# Altium schematic sheet Sheet_Robo_Hand_main.SchDoc(Sheet_Robo_Hand_main)
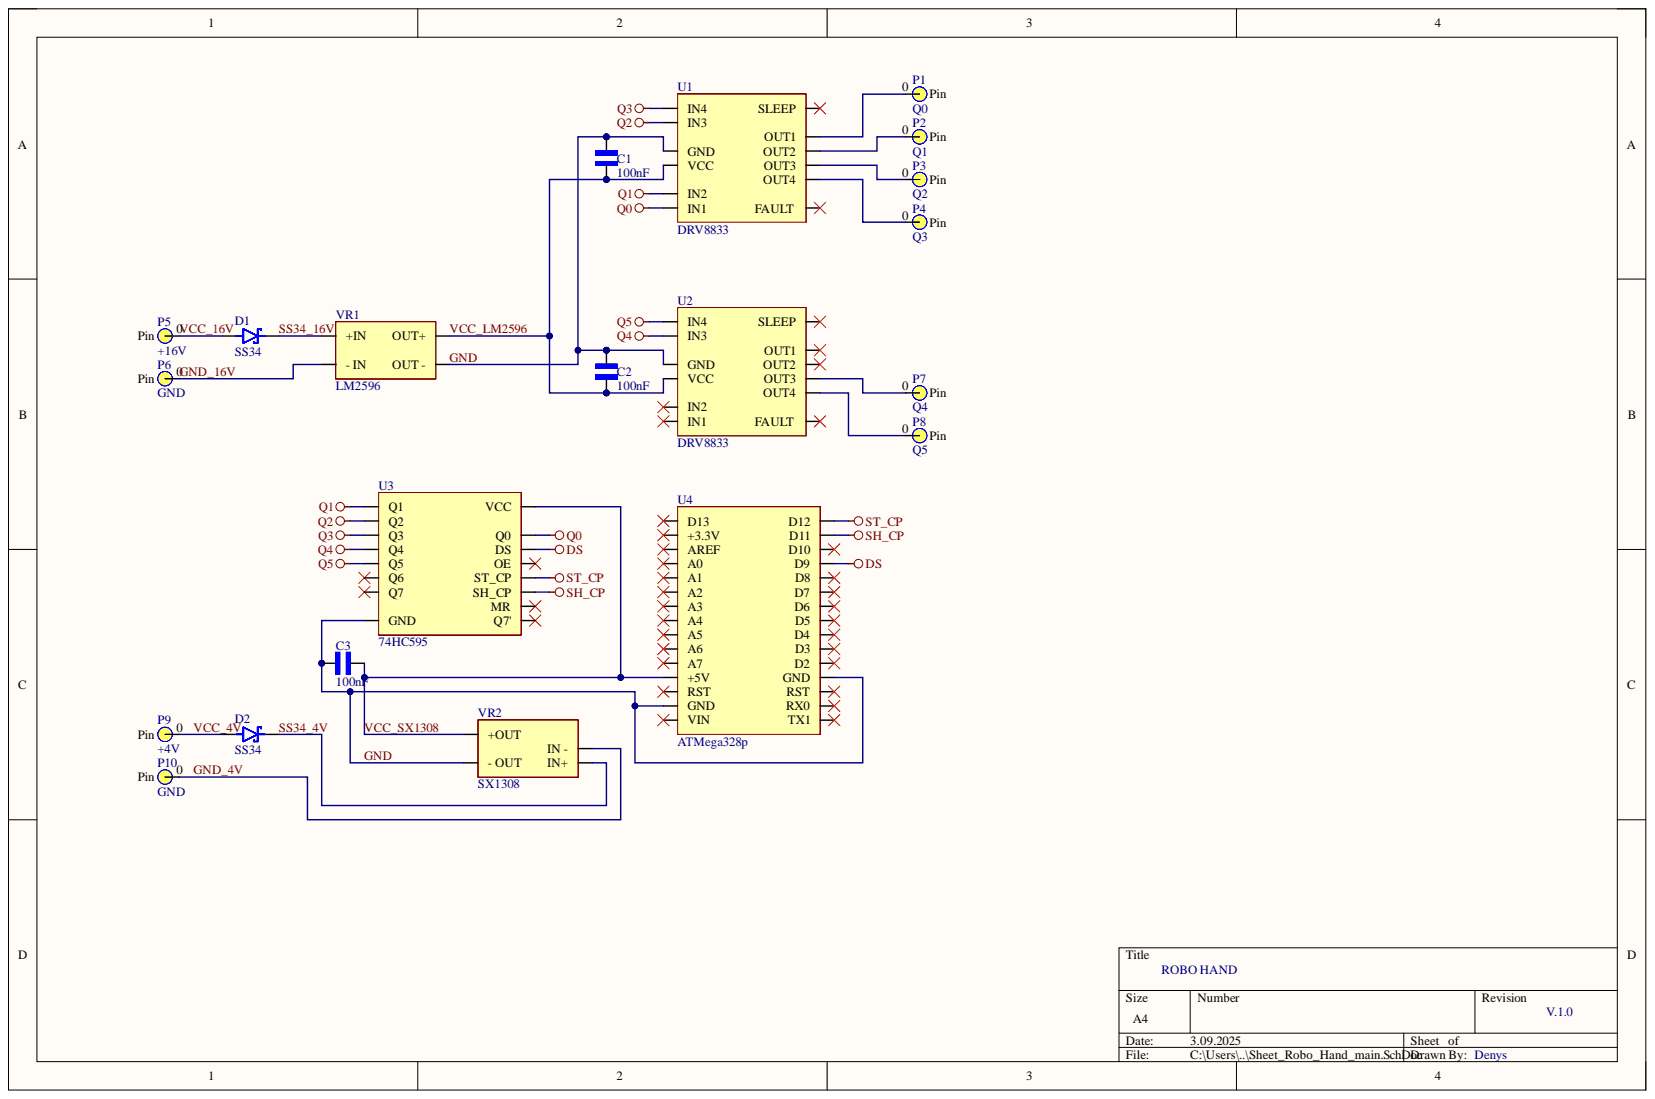
[diagram p0: Sheet_Robo_Hand_main.SchDoc]

## Parts
C1  100nF  [CAPC3216X180N]  (no BOM)
C2  100nF  [CAPC3216X180N]  (no BOM)
C3  100nF  [CAPC3216X180N]  (no BOM)
D1  SS34  [DIOM7959X265N]  (no BOM)
D2  SS34  [DIOM7959X265N]  (no BOM)
P1  Q0  [PCB_Pin_1]  (no BOM)
P2  Q1  [PCB_Pin_1]  (no BOM)
P3  Q2  [PCB_Pin_1]  (no BOM)
P4  Q3  [PCB_Pin_1]  (no BOM)
P5  +16V  [PCB_Pin_1]  (no BOM)
P6  GND  [PCB_Pin_1]  (no BOM)
P7  Q4  [PCB_Pin_1]  (no BOM)
P8  Q5  [PCB_Pin_1]  (no BOM)
P9  +4V  [PCB_Pin_1]  (no BOM)
P10  GND  [PCB_Pin_1]  (no BOM)
U1  DRV8833  [PCB_DRV8833]  (no BOM)
U2  DRV8833  [PCB_DRV8833]  (no BOM)
U3  74HC595  [PCB_74HC595_Footprint]  (no BOM)
U4  ATMega328p  [PCB_ATMega328p]  (no BOM)
VR1  LM2596  [DC-DC Step-Down Footprint]  (no BOM)
VR2  SX1308  [DC-DC Step-Up Footprint]  (no BOM)

## Nets
DS: U3.10(DS) U4.18(D9)
Q0: U1.0(IN1) U3.9(Q0)
Q1: U1.1(IN2) U3.7(Q1)
Q2: U1.4(IN3) U3.6(Q2)
Q3: U1.5(IN4) U3.5(Q3)
Q4: U2.4(IN3) U3.4(Q4)
Q5: U2.5(IN4) U3.3(Q5)
SH_CP: U3.13(SH_CP) U4.16(D11)
ST_CP: U3.12(ST_CP) U4.15(D12)
GND: C1.1 C2.2 C3.1 U1.3(GND) U2.3(GND) U3.0(GND) U4.1(GND) U4.26(GND) VR1.3(OUT_-) VR2.0(-_OUT)
GND_4V: P10.0 VR2.2(IN_-)
GND_16V: P6.0 VR1.0(-_IN)
SS34_4V: D2.C VR2.3(IN+)
SS34_16V: D1.C VR1.1(+IN)
VCC_4V: D2.A P9.0
VCC_16V: D1.A P5.0
VCC_LM2596: C1.2 C2.1 U1.2(VCC) U2.2(VCC) VR1.2(OUT+)
VCC_SX1308: C3.2 U3.8(VCC) U4.3(+5V) VR2.1(+OUT)
NetP1_0: P1.0 U1.7(OUT1)
NetP2_0: P2.0 U1.8(OUT2)
NetP3_0: P3.0 U1.9(OUT3)
NetP4_0: P4.0 U1.10(OUT4)
NetP7_0: P7.0 U2.9(OUT3)
NetP8_0: P8.0 U2.10(OUT4)

Not connected: U1 6(SLEEP) 11(FAULT); U2 0(IN1) 1(IN2) 6(SLEEP) 7(OUT1) 8(OUT2) 11(FAULT); U3 1(Q7) 2(Q6) 11(OE) 14(MR) 15(Q7'); U4 0(VIN) 2(RST) 4(A7) 5(A6) 6(A5) 7(A4) 8(A3) 9(A2) 10(A1) 11(A0) 12(AREF) 13(+3.3V) 14(D13) 17(D10) 19(D8) 20(D7) 21(D6) 22(D5) 23(D4) 24(D3) 25(D2) 27(RST) 28(RX0) 29(TX1)

## Library data of the parts
C1-C3 = C_1206_100nF: Ceramic capacitor SMD 1206 100 nF 50 V 10 % Samsung Electro-Mechanics CL31B104KBCNNNC 4000 pc(s); Availability: In Stock; Check_prices: https://www.snapeda.com/parts/CL31B104KBCNNNC/Samsung+Electro-Mechanics/view-part/?ref=eda; MF: Samsung Electro-Mechanics; MP: CL31B104KBCNNNC; Package: 1206 Samsung; Price: None; Purchase-URL: https://pricing.snapeda.com/search/part/CL31B104KBCNNNC/?ref=eda; SnapEDA_Link: https://www.snapeda.com/parts/CL31B104KBCNNNC/Samsung+Electro-Mechanics/view-part/?ref=snap; Library Name: С_1206_100nF.SchLib
D1 D2 = Diode_SS34: SS34 - діод Шоттки; MF: onsemi; Check_prices: https://www.snapeda.com/parts/SS34/Onsemi/view-part/?ref=eda; Library Name: Sch_SS34.SchLib; MP: SS34; Package: SMC-2 ON Semiconductor; SnapEDA_Link: https://www.snapeda.com/parts/SS34/Onsemi/view-part/?ref=snap
P1-P10 = PIN_1: Library Name: Sch_Pin_1.SchLib
U1 U2 = Motor Driver: Драйвер крокового/колекторних двигунів DRV8833; Library Name: Sch_DRV8833.SchLib
U3 = SCS-16: SN74HC595N - вихідний зсувний регістр 74HC595; Library Name: Sch_74HC595.SchLib
U4 = Arduino Nano: DCCduino Nano CH340 - аналог Arduino Nano v3.0 TYPE C; Library Name: Sch_ATMega328p.SchLib
VR1 = DC-DC Step-Down: DC-DC конвертер знижуючий 4.5...40В на 1.5...35В на LM2596; Library Name: Sch_LM2596.SchLib
VR2 = DC-DC Step-Up: Mini DC-DC конвертер підвищує 2.5..10В 5В/8В/9В/12В на SX1308; Library Name: Sch_SX1308.SchLib
